# Altium schematic sheet PWR.SchDoc(PWR)
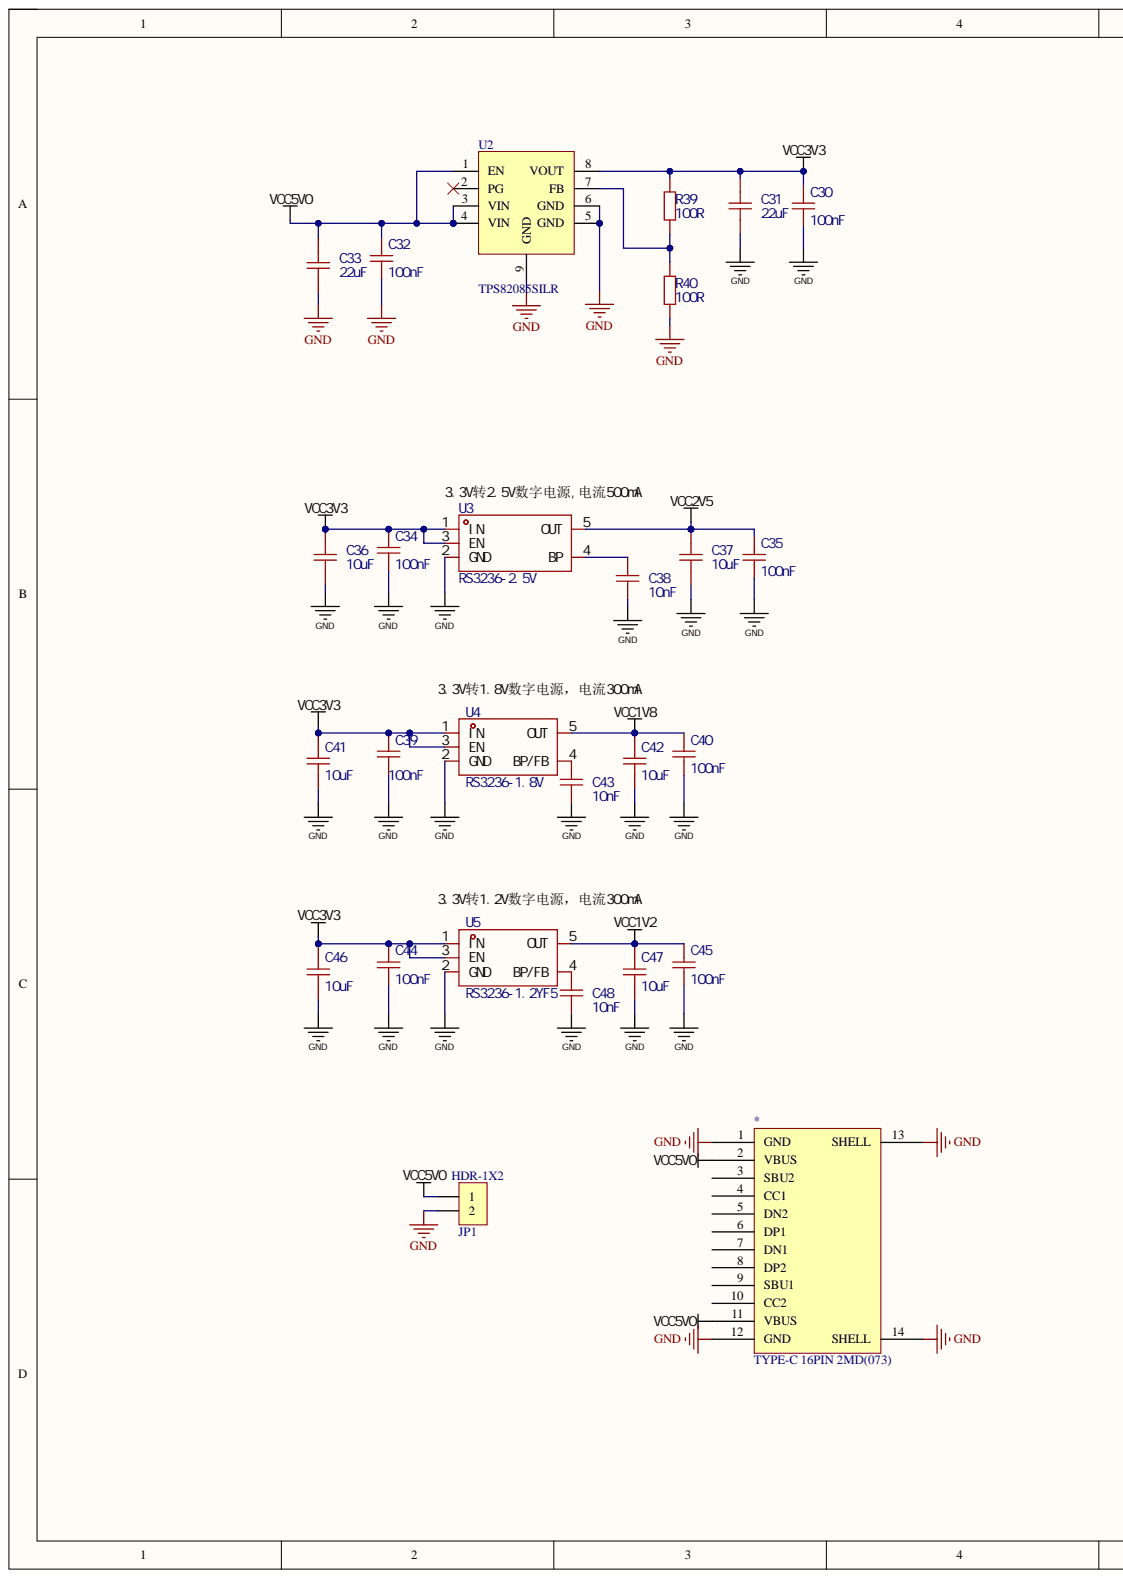
[diagram p0: PWR.SchDoc, left]
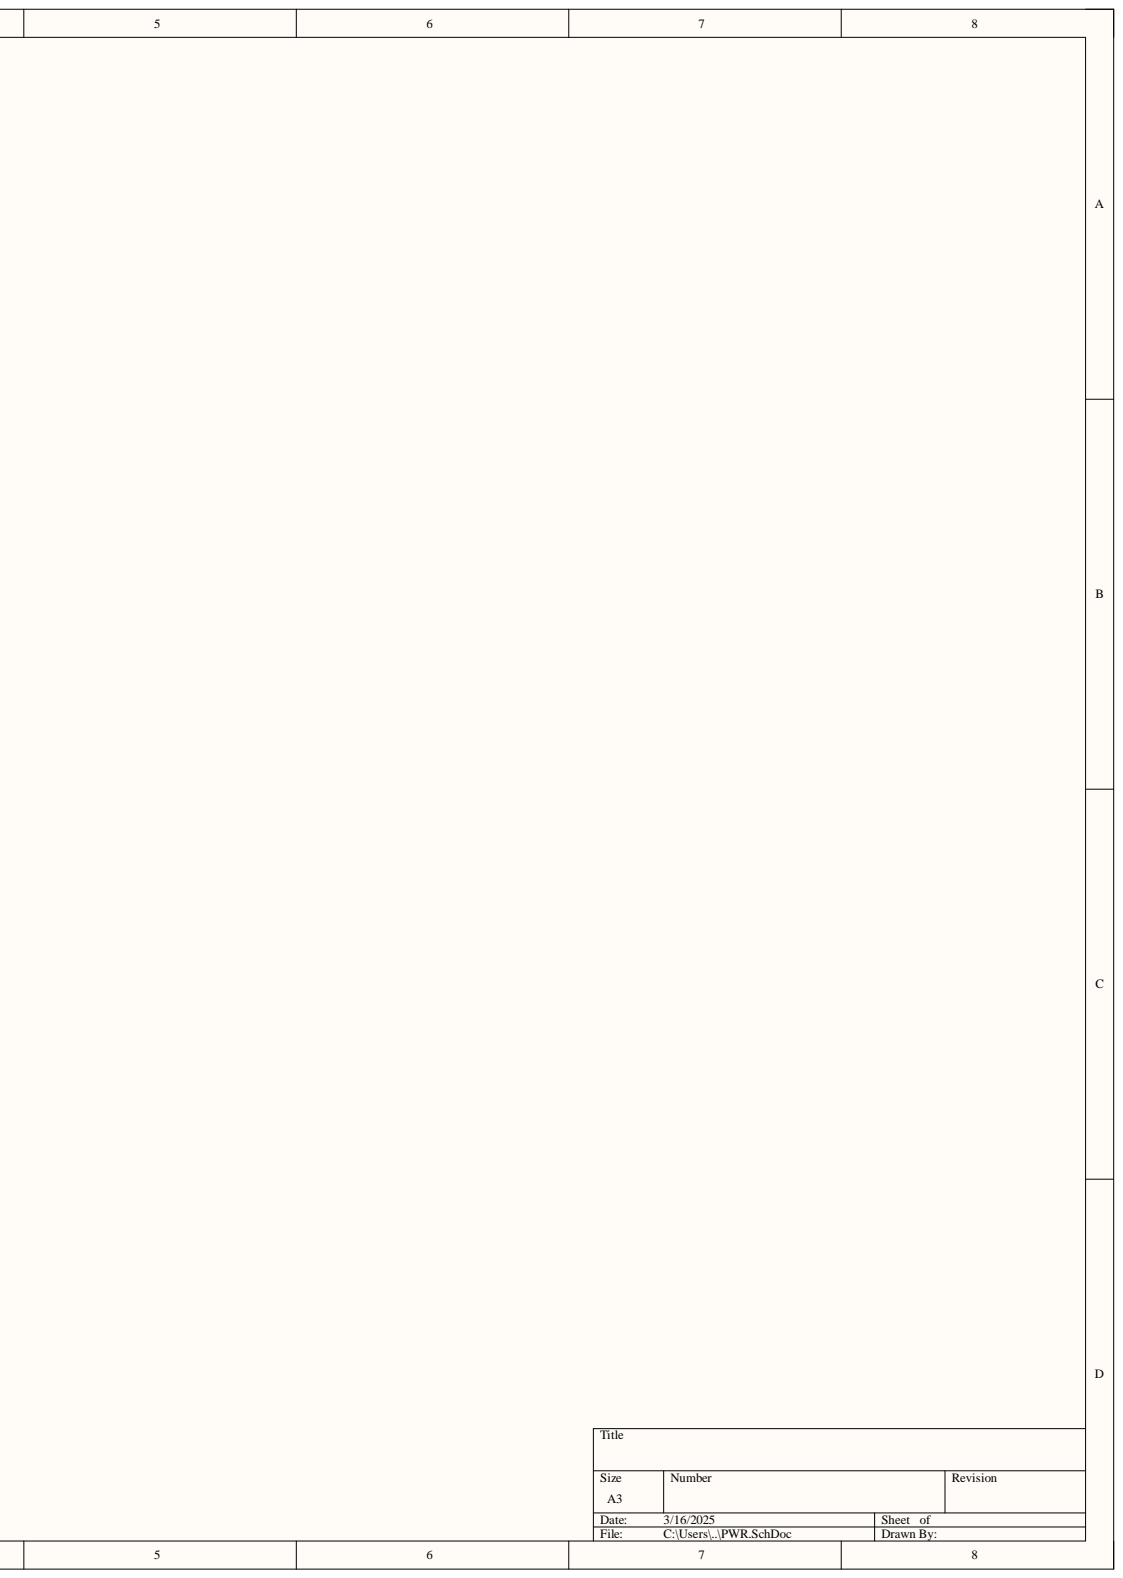
[diagram p1: PWR.SchDoc, right]

## Parts
*  TYPE-C 16PIN 2MD(073)  [USB-C-SMD_TYPE-C-6PIN-2MD-073]
C30  100nF  [0402]
C31  22uF  [1608[0603]]
C32  100nF  [0402]
C33  22uF  [1608[0603]]
C34  100nF  [0402]
C35  100nF  [0402]
C36  10uF  [1608[0603]]
C37  10uF  [1608[0603]]
C38  10nF  [0402]
C39  100nF  [0402]
C40  100nF  [0402]
C41  10uF  [1608[0603]]
C42  10uF  [1608[0603]]
C43  10nF  [0402]
C44  100nF  [0402]
C45  100nF  [0402]
C46  10uF  [1608[0603]]
C47  10uF  [1608[0603]]
C48  10nF  [0402]
JP1  HDR-1X2  [CONN-TH_2P-P2.50_HX25003-2A]
R39  100R  [0402]
R40  100R  [0402]
U2  TPS82085SILR  [USIP-8_L3.0-W2.8-P0.65-BL-EP]
U3  RS3236-2.5V  [SOT-23-5_L2.9-W1.6-P0.95-LS2.8-BL]
U4  RS3236-1.8V  [SOT-23-5_L2.9-W1.6-P0.95-LS2.8-BL]
U5  RS3236-1.2YF5  [SOT-23-5_L2.9-W1.6-P0.95-LS2.8-BL]

## Nets
GND: *.1(GND) *.12(GND) *.13(SHELL) *.14(SHELL) C30.1 C31.1 C32.1 C33.1 C34.1 C35.1 C36.1 C37.1 C38.1 C39.1 C40.1 C41.1 C42.1 C43.1 C44.1 C45.1 C46.1 C47.1 C48.1 JP1.2 R40.2 U2.5(GND) U2.6(GND) U2.9(GND) U3.2(GND) U4.2(GND) U5.2(GND)
VCC1V2: C45.2 C47.2 U5.5(OUT)
VCC1V8: C40.2 C42.2 U4.5(OUT)
VCC2V5: C35.2 C37.2 U3.5(OUT)
VCC3V3: C30.2 C31.2 C34.2 C36.2 C39.2 C41.2 C44.2 C46.2 R39.1 U2.8(VOUT) U3.1(IN) U3.3(EN) U4.1(IN) U4.3(EN) U5.1(IN) U5.3(EN)
VCC5V0: *.2(VBUS) *.11(VBUS) C32.2 C33.2 JP1.1 U2.1(EN) U2.3(VIN) U2.4(VIN)
NetC38_2: C38.2 U3.4(BP)
NetC43_2: C43.2 U4.4(BP/FB)
NetC48_2: C48.2 U5.4(BP/FB)
NetR39_2: R39.2 R40.1 U2.7(FB)

Not connected: * 3(SBU2) 4(CC1) 5(DN2) 6(DP1) 7(DN1) 8(DP2) 9(SBU1) 10(CC2); U2 2(PG)

## Library data of the parts
C30 C32 C34 C35 C39 C40 C44 C45 = 0402B104K500NT: 容值:100nF;精度:±10%;额定电压:50V;材质(温度系数):X7R;; Manufacturer: FH(风华); Add into BOM: yes; Temperature Coefficient: X7R; Tolerance: ±10%; Voltage Rated: 50V; Component Kind: Standard; Convert to PCB: yes; Datasheet: https://atta.szlcsc.com/upload/public/pdf/source/20200305/C486355_26A10D38EF8C2A5C37091D0039909270.pdf; Device: 0402B104K500NT; JLCPCB Part Class: 扩展库; LCSC Part Name: 100nF ±10% 50V; Manufacturer Part: 0402B104K500NT; PCB3D: C0402_L1.0-W0.5-H0.5; Supplier: LCSC; Supplier Footprint: 0402; Supplier Part: C110251; Symbol: 0402B104K500NT; Value: 100nF
C31 C33 = 0402X106M100NT: 容值:10uF;精度:±20%;额定电压:10V;材质(温度系数):X5R;; Manufacturer: FH(风华); Add into BOM: yes; Temperature Coefficient: X5R; Tolerance: ±20%; Value: 10uF; Voltage Rated: 10V; Component Kind: Standard; Convert to PCB: yes; Datasheet: https://atta.szlcsc.com/upload/public/pdf/source/20220926/AA4F6BD26C0E1EEE4FC2D2A30769307C.pdf; Device: 0402X106M100NT; JLCPCB Part Class: 扩展库; LCSC Part Name: 10uF ±20% 10V; Manufacturer Part: 0402X106M100NT; PCB3D: C0402_L1.0-W0.5-H0.5; Supplier: LCSC; Supplier Footprint: 0402; Supplier Part: C2846677; Symbol: 0402X106M100NT
C36 C37 C41 C42 C46 C47 = 0402X106M100NT: 容值:10uF;精度:±20%;额定电压:10V;材质(温度系数):X5R;; Manufacturer: FH(风华); Add into BOM: yes; Temperature Coefficient: X5R; Tolerance: ±20%; Voltage Rated: 10V; Component Kind: Standard; Convert to PCB: yes; Datasheet: https://atta.szlcsc.com/upload/public/pdf/source/20220926/AA4F6BD26C0E1EEE4FC2D2A30769307C.pdf; Device: 0402X106M100NT; JLCPCB Part Class: 扩展库; LCSC Part Name: 10uF ±20% 10V; Manufacturer Part: 0402X106M100NT; PCB3D: C0402_L1.0-W0.5-H0.5; Supplier: LCSC; Supplier Footprint: 0402; Supplier Part: C2846677; Symbol: 0402X106M100NT; Value: 10uF
C38 C43 C48 = 0402B103K500NT: 容值:10nF;精度:±10%;额定电压:50V;材质(温度系数):X7R;; Manufacturer: FH(风华); Add into BOM: yes; Temperature Coefficient: X7R; Tolerance: ±10%; Voltage Rated: 50V; Component Kind: Standard; Convert to PCB: yes; Datasheet: https://atta.szlcsc.com/upload/public/pdf/source/20200305/C486355_26A10D38EF8C2A5C37091D0039909270.pdf; Device: 0402B103K500NT; JLCPCB Part Class: 扩展库; LCSC Part Name: 10nF ±10% 50V; Manufacturer Part: 0402B103K500NT; PCB3D: C0402_L1.0-W0.5-H0.5; Supplier: LCSC; Supplier Footprint: 0402; Supplier Part: C1524; Symbol: 0402B103K500NT; Value: 10nF
JP1 = Header 2: 2P接插件; 联系卖家，免费技术支持: 唯一出售店铺网址https://shop463601444.taobao.com; BOM名称: 2P接插件; Component Kind: Standard; Library Name: 6.1 - 5.08mm连接器(KF128-KF2EDGK-KF2EDGVHM-5.08).SchLib
R39 R40 = RC-02K4422FT: 电阻类型:厚膜电阻;阻值:44.2kΩ;精度:±1%;功率:62.5mW;最大工作电压:50V;温度系数:±100ppm/℃;; Manufacturer: FH(风华); Add into BOM: yes; Overload Voltage (Max): 50V; Power(Watts): 62.5mW; Symbol: RS-02K4422FT; Tolerance: ±1%; Value: 44.2kΩ; Component Kind: Standard; Convert to PCB: yes; Datasheet: https://atta.szlcsc.com/upload/public/pdf/source/20140616/1457707091974.pdf; Device: RC-02K4422FT; JLCPCB Part Class: 扩展库; LCSC Part Name: 厚膜电阻 44.2kΩ ±1% 62.5mW; Manufacturer Part: RC-02K4422FT; Operating Temperature Range: -55℃~+155℃; PCB3D: R0402_L1.0-W0.5-H0.5; Supplier: LCSC; Supplier Footprint: 0402; Supplier Part: C140153; Temperature Coefficient: ±100ppm/℃; Type: 厚膜电阻; Library Name: schdoc1.SCHLIB
U3 = RS3236-1.8YF5: 输出类型:固定;最大输入电压:7.5V;输出电压:1.8V;最大输出电流:500mA;电源纹波抑制比(PSRR):70dB@(1kHz);输出极性:正极;; Manufacturer: RUNIC(润石); Add into BOM: yes; Dropout Voltage: 550mV@(300mA); MAX Output Current: 500mA; Maximum Input Voltage: 7.5V; Number of Outputs: 1; Output Polarity: 正极; Output Type: 固定; Output Voltage: 1.8V; standby current: 40uA; Component Kind: Standard; Convert to PCB: yes; Datasheet: https://atta.szlcsc.com/upload/public/pdf/source/20190312/C379350_C6E838C63CD1E04DE7C7AC39BE8996D9.pdf; Device: RS3236-1.8YF5; JLCPCB Part Class: 扩展库; LCSC Part Name: 输入7.5V 输出1.8V~1.8V 500mA; Manufacturer Part: RS3236-1.8YF5; Operating Temperature: -40℃~+85℃@(Ta); PCB3D: SOT-23-5_L2.9-W1.6-P0.95-LS2.8-BL; Power Supply Rejection Ratio (PSRR): 70dB@(1kHz); Supplier: LCSC; Supplier Footprint: SOT-23-5; Supplier Part: C379343; Symbol: RS3236-1.8YF5
U4 U5 = RS3236-1.2YF5: 输出类型:-;; Manufacturer: RUNIC(润石); Add into BOM: yes; Component Kind: Standard; Convert to PCB: yes; Datasheet: https://atta.szlcsc.com/upload/public/pdf/source/20210326/C2762826_4933D9B6AE509F284B660A7D9A13C953.pdf; Device: RS3236-1.2YF5; JLCPCB Part Class: 扩展库; LCSC Part Name: RS3236-1.2V; Manufacturer Part: RS3236-1.2YF5; Output Type: -; PCB3D: SOT-23-5_L2.9-W1.6-P0.95-LS2.8-BL; Supplier: LCSC; Supplier Footprint: SOT-23-5; Supplier Part: C2762825; Symbol: RS3236-1.2YF5
* = TYPE-C 16PIN 2MD(073): Component Kind: Standard; Library Name: Schlib1.SchLib
U2 = TPS82085SILR: Component Kind: Standard; Library Name: Schlib1.SchLib
